# Altium schematic sheet 05_PWMdriver.SchDoc(05_PWMdriver)
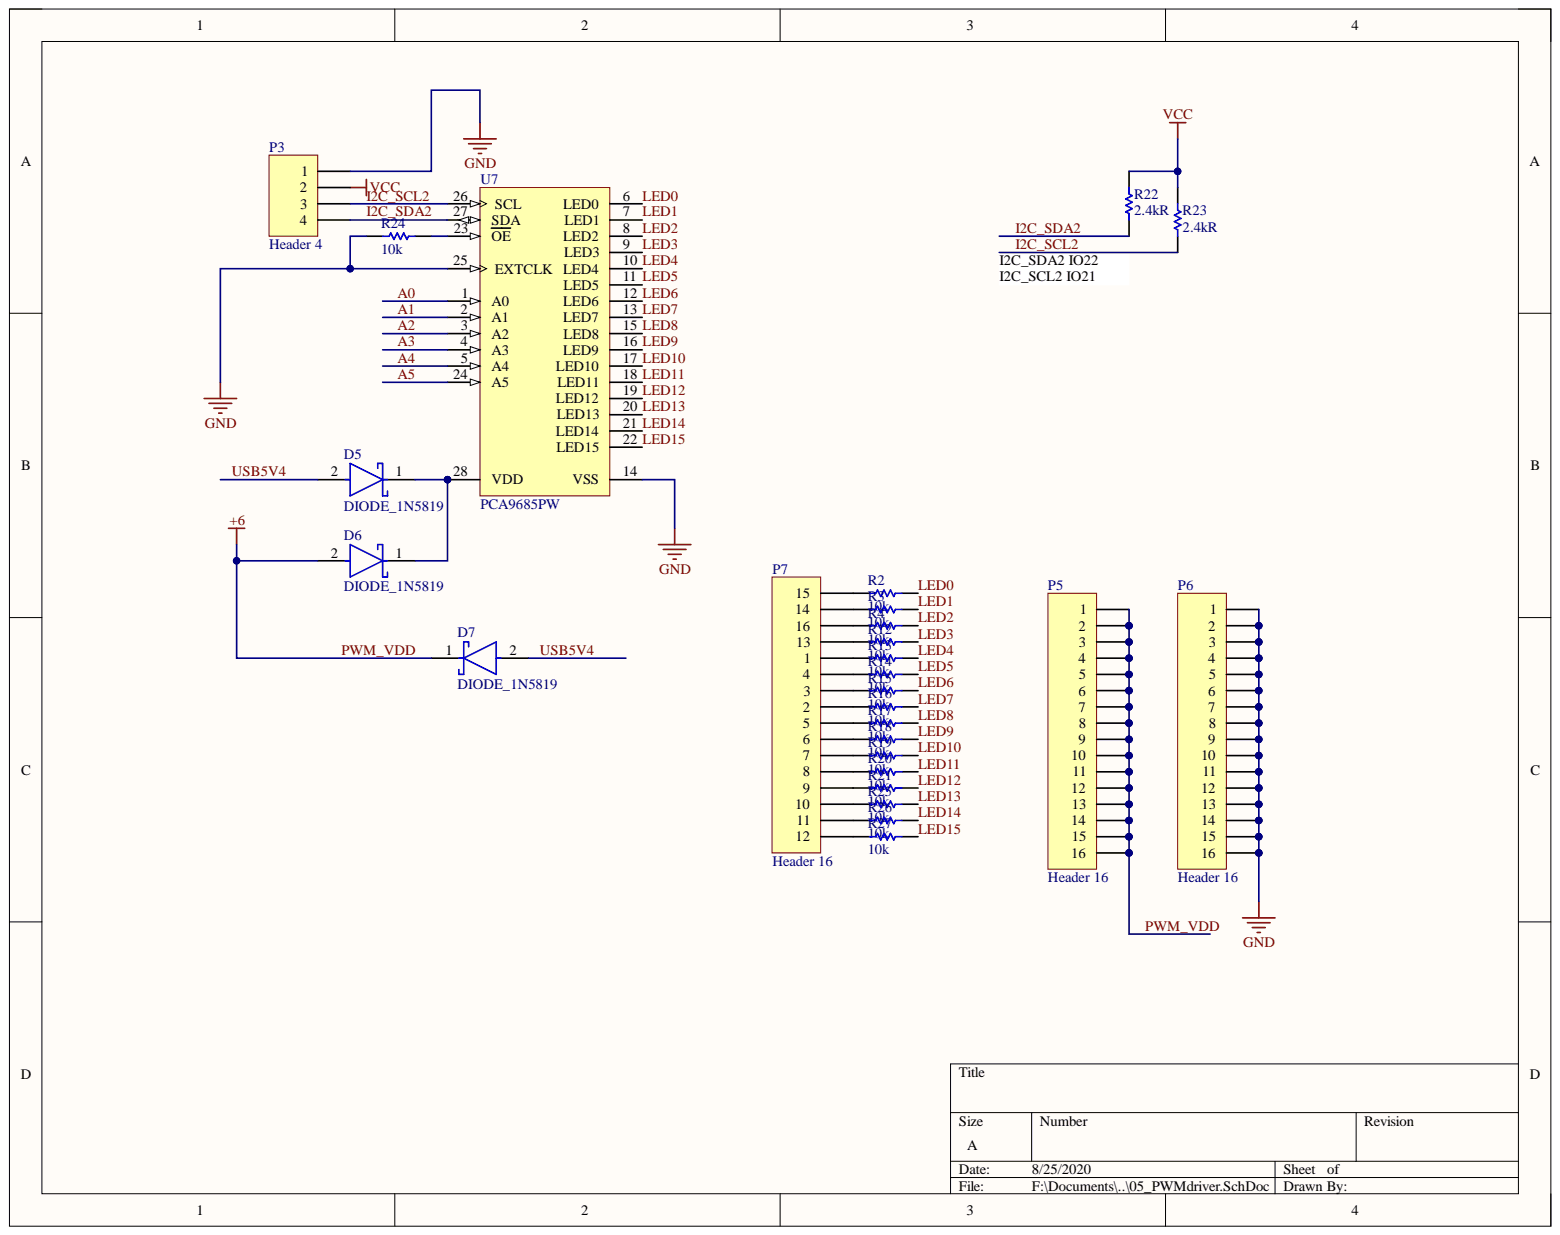
[diagram p0: 05_PWMdriver.SchDoc]

## Parts
D5  DIODE_1N5819  [FP-SOD123-MFG]
D6  DIODE_1N5819  [FP-SOD123-MFG]
D7  DIODE_1N5819  [FP-SOD123-MFG]
P3  Header 4  [HDR1X4]
P5  Header 16  [HDR1X16]
P6  Header 16  [HDR1X16]
P7  Header 16  [HDR1X16]
R2  value 10k  [RESC1609X55X30LL15T15]  (hidden comment: R0603_10K)
R3  value 10k  [RESC1609X55X30LL15T15]  (hidden comment: R0603_10K)
R4  value 10k  [RESC1609X55X30LL15T15]  (hidden comment: R0603_10K)
R12  value 10k  [RESC1609X55X30LL15T15]  (hidden comment: R0603_10K)
R13  value 10k  [RESC1609X55X30LL15T15]  (hidden comment: R0603_10K)
R14  value 10k  [RESC1609X55X30LL15T15]  (hidden comment: R0603_10K)
R15  value 10k  [RESC1609X55X30LL15T15]  (hidden comment: R0603_10K)
R16  value 10k  [RESC1609X55X30LL15T15]  (hidden comment: R0603_10K)
R17  value 10k  [RESC1609X55X30LL15T15]  (hidden comment: R0603_10K)
R18  value 10k  [RESC1609X55X30LL15T15]  (hidden comment: R0603_10K)
R19  value 10k  [RESC1609X55X30LL15T15]  (hidden comment: R0603_10K)
R20  value 10k  [RESC1609X55X30LL15T15]  (hidden comment: R0603_10K)
R21  value 10k  [RESC1609X55X30LL15T15]  (hidden comment: R0603_10K)
R22  value 2.4kR  [RESC1608X50X30ML20T20]  (hidden comment: R0603_2.4K)
R23  value 2.4kR  [RESC1608X50X30ML20T20]  (hidden comment: R0603_2.4K)
R24  value 10k  [RESC1609X55X30LL15T15]  (hidden comment: R0603_10K)
R25  value 10k  [RESC1609X55X30LL15T15]  (hidden comment: R0603_10K)
R26  value 10k  [RESC1609X55X30LL15T15]  (hidden comment: R0603_10K)
R27  value 10k  [RESC1609X55X30LL15T15]  (hidden comment: R0603_10K)
U7  PCA9685PW  [SOT361-1_V]

## Nets
GND: P3.1 P6.1 P6.2 P6.3 P6.4 P6.5 P6.6 P6.7 P6.8 P6.9 P6.10 P6.11 P6.12 P6.13 P6.14 P6.15 P6.16 R24.2 U7.14(VSS) U7.25(EXTCLK)
VCC: P3.2 R22.2 R23.2
A0: U7.1(A0)
A1: U7.2(A1)
A2: U7.3(A2)
A3: U7.4(A3)
A4: U7.5(A4)
A5: U7.24(A5)
I2C_SCL2: P3.3 R23.1 U7.26(SCL)
I2C_SDA2: P3.4 R22.1 U7.27(SDA)
LED0: R2.1 U7.6(LED0)
LED1: R3.1 U7.7(LED1)
LED2: R4.1 U7.8(LED2)
LED3: R12.1 U7.9(LED3)
LED4: R13.1 U7.10(LED4)
LED5: R14.1 U7.11(LED5)
LED6: R15.1 U7.12(LED6)
LED7: R16.1 U7.13(LED7)
LED8: R17.1 U7.15(LED8)
LED9: R18.1 U7.16(LED9)
LED10: R19.1 U7.17(LED10)
LED11: R20.1 U7.18(LED11)
LED12: R21.1 U7.19(LED12)
LED13: R25.1 U7.20(LED13)
LED14: R26.1 U7.21(LED14)
LED15: R27.1 U7.22(LED15)
PWM_VDD: D6.2 D7.1 P5.1 P5.2 P5.3 P5.4 P5.5 P5.6 P5.7 P5.8 P5.9 P5.10 P5.11 P5.12 P5.13 P5.14 P5.15 P5.16
USB5V4: D5.2 D7.2
NetD5_1: D5.1 D6.1 U7.28(VDD)
NetP7_1: P7.1 R13.2
NetP7_2: P7.2 R16.2
NetP7_3: P7.3 R15.2
NetP7_4: P7.4 R14.2
NetP7_5: P7.5 R17.2
NetP7_6: P7.6 R18.2
NetP7_7: P7.7 R19.2
NetP7_8: P7.8 R20.2
NetP7_9: P7.9 R21.2
NetP7_10: P7.10 R25.2
NetP7_11: P7.11 R26.2
NetP7_12: P7.12 R27.2
NetP7_13: P7.13 R12.2
NetP7_14: P7.14 R3.2
NetP7_15: P7.15 R2.2
NetP7_16: P7.16 R4.2
NetR24_1: R24.1 U7.23(OE)

## Library data of the parts
D5-D7 = CMP-12790-000018-1: DIODE SCHOTTKY 40V 1A SOD123; Manufacturer: Diodes Inc; Manufacturer Part Number: 1N5819HW-7-F; Capacitance: 50pF; Ciiva Ids: 815630; If A: 1; Import Ts: 1521843113; Max Junction Temp: +125°C; Max Surge Forward Current: 25A; Peak Reverse Current: 1mA; Standoff Height: 0mm; Value: 50pF; Vr V: 40; Automotive: No; Category: Diode; Component Kind: Standard; ComponentLink2Description: Datasheet; ComponentLink2URL: https://www.diodes.com/assets/Datasheets/ds30217.pdf; Device Class L1: Discrete Semiconductors; Device Class L2: Diodes; Device Class L3: Schottky Diodes; Digikey Description: DIODE SCHOTTKY 40V 1A SOD123; Footprint Url: https://www.diodes.com/assets/Package-Files/SOD123.pdf; Forward Current: 1A; Height: 1.45mm; Ipc Land Pattern Name: SOD365155X123-2; Lead Free: Yes; Library Name: Altium Content Vault; Max Repetitive Reverse Voltage: 40V; Mouser Description: 1N5819HW Series 40 V 1 A Surface Mount Schottky Barrier Rectifier - SOD-123; Octopart: https://octopart.com/1n5819hw-7-f-diodes+inc.-323197; Package: SOD123; Release Date: 1385348939; Reverse Voltage: 40V; RoHS: TRUE; Temperature: -65°C to +125°C; Temperature Range High: +125°C; Temperature Range Low: -65°C; Terminal Temperature Tt Degc: 90
P3 = Header 4: Header, 4-Pin; Component Kind: Standard; LatestRevisionDate: 17-Jul-2002; LatestRevisionNote: Re-released for DXP Platform.; Library Name: Miscellaneous Connectors.IntLib; Signal Integrity: Connector; Publisher: Altium Limited
P5-P7 = Header 16: Header, 16-Pin; Component Kind: Standard; LatestRevisionDate: 17-Jul-2002; LatestRevisionNote: Re-released for DXP Platform.; Library Name: Miscellaneous Connectors.IntLib; Signal Integrity: Connector; Publisher: Altium Limited
R2-R4 R12-R21 R24-R27 = CMP-2000-07452-1: Chip Resistor, 10 KOhm, +/- 1%, 0.1 W, -55 to 155 degC, 0603 (1608 Metric), RoHS, Tape and Reel; Manufacturer: Panasonic; Case\/Package: 0603; Max Power Dissipation: 100mW; Resistance: 10KOhm; Temperature Coefficient: 100ppm\/degreesC; Voltage Rating: 75V; Case Code (Imperial): 0603; Case Code (Metric): 1608; Component Kind: Standard; Composition: ThickFilm; Contact Plating: Tin; Datasheet URL: https://industrial.panasonic.com/cdbs/www-data/pdf/RDA0000/AOA0000C304.pdf; Datasheet Version: 02/2017; Depth: 0.8mm; Height: 0.45mm; Lead Free: LeadFree; Length: 1.6mm; Library Name: Altium Content Vault; Manufacturer URL: http://www.panasonic.com/; Max Operating Temperature: 155degC; Military Standard: Not; Min Operating Temperature: -55degC; Mount: SurfaceMount; Mounting Technology: SurfaceMount; Number of Pins: 2; Number of Terminations: 2; Package Description: 2-Pin Surface Mount Device, Body 1.6 x 0.85 mm; Package Quantity: 1; Package Reference: 603; Packaging: TapeandReel; Power Rating: 100mW; Radiation Hardening: No; REACH SVHC: NoSVHC; RoHSCompliant: true; Termination: SMD/SMT; Tolerance: 1%; Voltage Rating (DC): 75V; Width: 0.031inch
R22 R23 = CMP-2000-02561-1: Manufacturer: Susumu; Part Number: RR0816P-242-D; Component Kind: Standard; Library Name: Altium Content Vault
U7 = CMP-1595-00004-2: 16-Channel, 12-Bit PWM Fm+ I2C-Bus LED Controller, 2.3 to 5.5 V, -40 to 85 degC, 28-Pin TSSOP (SOT361-1), RoHS, Tape and Reel; Frequency: 25000000 Hz; Supply Current Max: 10 mA; Supply Voltage Max: 5.5 V; Supply Voltage Min: 2.3 V; Code_JEDEC: MO-153; Component Kind: Standard; ComponentLink1Description: Manufacturer URL; ComponentLink1URL: http://www.nxp.com/; ComponentLink2Description: Datasheet; ComponentLink2URL: http://www.nxp.com/documents/data_sheet/PCA9685.pdf; ComponentLink3Description: Package Specification; ComponentLink3URL: http://www.nxp.com/documents/outline_drawing/sot361-1_po.pdf; DatasheetVersion: Rev. 4; Library Name: Altium Content Vault; Mounting Technology: Surface Mount; PackageDescription: 28-Pin Small Outline Package, Body 9.7 x 4.4 mm, Pitch 0.65 mm; PackageVersion: 02/2003; Packing: Tape and Reel; PartNumber: PCA9685; RoHS: TRUE; Temperature Max: 85 degC; Temperature Min: -40 degC
